# Altium schematic sheet STM32F103C8T6接口&扩展板.SchDoc
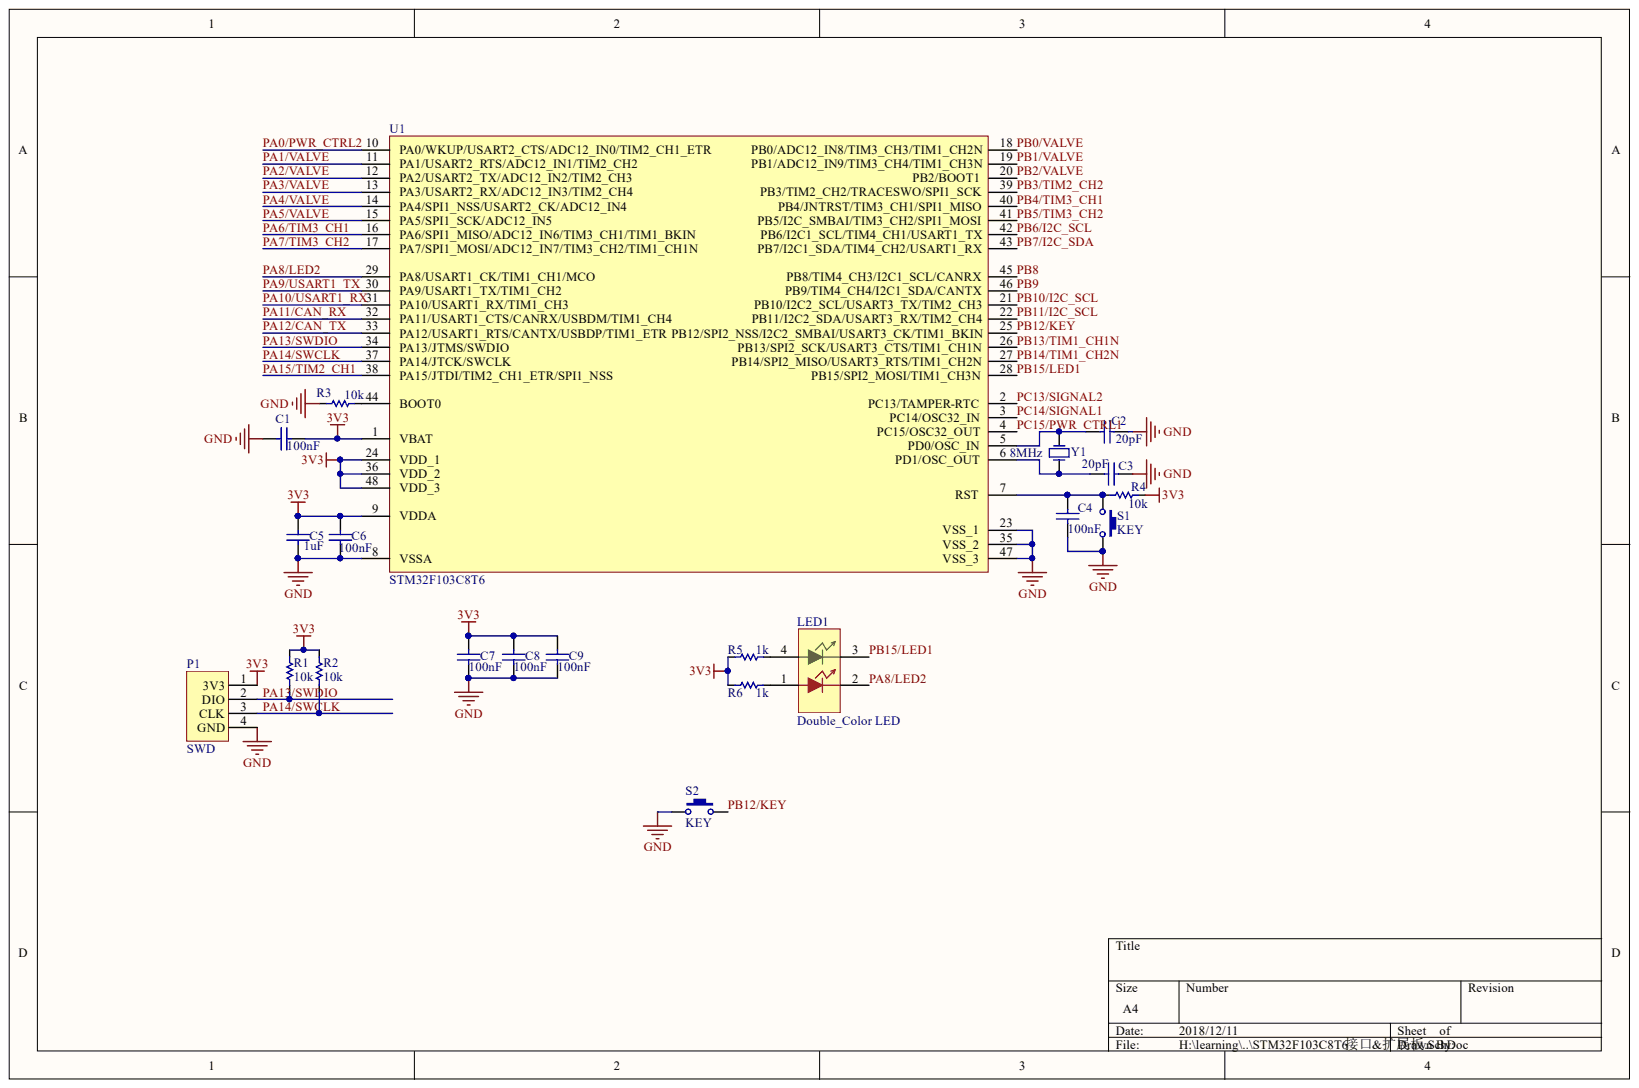
[diagram p0: STM32F103C8T6接口&扩展板.SchDoc]

## Parts
C1  100nF  [0603C]
C2  20pF  [0603C]
C3  20pF  [0603C]
C4  100nF  [0603C]
C5  1uF  [0603C]
C6  100nF  [0603C]
C7  100nF  [0603C]
C8  100nF  [0603C]
C9  100nF  [0603C]
LED1  Double_Color LED  [0805LEDX2]
P1  SWD  [HEADER 4x1]
R1  10k  [0603R]
R2  10k  [0603R]
R3  10k  [0603R]
R4  10k  [0603R]
R5  1k  [0603R]
R6  1k  [0603R]
S1  KEY  [SW 3mmx4mm]
S2  KEY  [SW 3mmx4mm]
U1  STM32F103C8T6  [LQFP_48]
Y1  8MHz  [NX5032GA]

## Nets
3V3: C1.2 C5.1 C6.1 C7.1 C8.1 C9.1 P1.1(3V3) R1.2 R2.2 R4.2 R5.1 R6.1 U1.1(VBAT) U1.9(VDDA) U1.24(VDD_1) U1.36(VDD_2) U1.48(VDD_3)
GND: C1.1 C2.2 C3.2 C4.2 C5.2 C6.2 C7.2 C8.2 C9.2 P1.4(GND) R3.2 S1.2 S2.1 U1.8(VSSA) U1.23(VSS_1) U1.35(VSS_2) U1.47(VSS_3)
PA0/PWR_CTRL2: U1.10(PA0/WKUP/USART2_CTS/ADC12_IN0/TIM2_CH1_ETR)
PA1/VALVE: U1.11(PA1/USART2_RTS/ADC12_IN1/TIM2_CH2)
PA2/VALVE: U1.12(PA2/USART2_TX/ADC12_IN2/TIM2_CH3)
PA3/VALVE: U1.13(PA3/USART2_RX/ADC12_IN3/TIM2_CH4)
PA4/VALVE: U1.14(PA4/SPI1_NSS/USART2_CK/ADC12_IN4)
PA5/VALVE: U1.15(PA5/SPI1_SCK/ADC12_IN5)
PA6/TIM3_CH1: U1.16(PA6/SPI1_MISO/ADC12_IN6/TIM3_CH1/TIM1_BKIN)
PA7/TIM3_CH2: U1.17(PA7/SPI1_MOSI/ADC12_IN7/TIM3_CH2/TIM1_CH1N)
PA8/LED2: LED1.2 U1.29(PA8/USART1_CK/TIM1_CH1/MCO)
PA9/USART1_TX: U1.30(PA9/USART1_TX/TIM1_CH2)
PA10/USART1_RX: U1.31(PA10/USART1_RX/TIM1_CH3)
PA11/CAN_RX: U1.32(PA11/USART1_CTS/CANRX/USBDM/TIM1_CH4)
PA12/CAN_TX: U1.33(PA12/USART1_RTS/CANTX/USBDP/TIM1_ETR)
PA13/SWDIO: P1.2(DIO) R1.1 U1.34(PA13/JTMS/SWDIO)
PA14/SWCLK: P1.3(CLK) R2.1 U1.37(PA14/JTCK/SWCLK)
PA15/TIM2_CH1: U1.38(PA15/JTDI/TIM2_CH1_ETR/SPI1_NSS)
PB0/VALVE: U1.18(PB0/ADC12_IN8/TIM3_CH3/TIM1_CH2N)
PB1/VALVE: U1.19(PB1/ADC12_IN9/TIM3_CH4/TIM1_CH3N)
PB2/VALVE: U1.20(PB2/BOOT1)
PB3/TIM2_CH2: U1.39(PB3/TIM2_CH2/TRACESWO/SPI1_SCK)
PB4/TIM3_CH1: U1.40(PB4/JNTRST/TIM3_CH1/SPI1_MISO)
PB5/TIM3_CH2: U1.41(PB5/I2C_SMBAI/TIM3_CH2/SPI1_MOSI)
PB6/I2C_SCL: U1.42(PB6/I2C1_SCL/TIM4_CH1/USART1_TX)
PB7/I2C_SDA: U1.43(PB7/I2C1_SDA/TIM4_CH2/USART1_RX)
PB8: U1.45(PB8/TIM4_CH3/I2C1_SCL/CANRX)
PB9: U1.46(PB9/TIM4_CH4/I2C1_SDA/CANTX)
PB10/I2C_SCL: U1.21(PB10/I2C2_SCL/USART3_TX/TIM2_CH3)
PB11/I2C_SCL: U1.22(PB11/I2C2_SDA/USART3_RX/TIM2_CH4)
PB12/KEY: S2.2 U1.25(PB12/SPI2_NSS/I2C2_SMBAI/USART3_CK/TIM1_BKIN)
PB13/TIM1_CH1N: U1.26(PB13/SPI2_SCK/USART3_CTS/TIM1_CH1N)
PB14/TIM1_CH2N: U1.27(PB14/SPI2_MISO/USART3_RTS/TIM1_CH2N)
PB15/LED1: LED1.3 U1.28(PB15/SPI2_MOSI/TIM1_CH3N)
PC13/SIGNAL2: U1.2(PC13/TAMPER-RTC)
PC14/SIGNAL1: U1.3(PC14/OSC32_IN)
PC15/PWR_CTRL1: U1.4(PC15/OSC32_OUT)
NetC2_1: C2.1 U1.5(PD0/OSC_IN) Y1.2
NetC3_1: C3.1 U1.6(PD1/OSC_OUT) Y1.1
NetC4_1: C4.1 R4.1 S1.1 U1.7(RST)
NetLED1_1: LED1.1 R6.2
NetLED1_4: LED1.4 R5.2
NetR3_1: R3.1 U1.44(BOOT0)

## Library data of the parts
C1-C9 = Cap: Capacitor; Value: 100pF; Component Kind: Standard; LatestRevisionDate: 17-Jul-2002; LatestRevisionNote: Re-released for DXP Platform.; Library Name: MyComponents_v2.3.IntLib; PackageDescription: Radial Cap, Thru-Hole; 2 Leads; 0.3 in Pin Spacing; PackageReference: RAD-0.3; Published: 8-Jun-2000; Signal Integrity: Cap; Simulation: CAP; Publisher: Altium Limited
P1 = SWD_HEADER: Component Kind: Standard; Library Name: MyComponents_v2.5.IntLib
R1-R4 = Res1: Resistor; Value: 1K; Component Kind: Standard; LatestRevisionDate: 17-Jul-2002; LatestRevisionNote: Re-released for DXP Platform.; Library Name: MyComponents_v2.3.IntLib; PackageDescription: Axial Device, Thru-Hole; 2 Leads; 0.3 in Pin Spacing; PackageReference: AXIAL-0.3; Published: 8-Jun-2000; Signal Integrity: Res; Simulation: RESISTOR; Publisher: Altium Limited
R5 R6 = Res1: Resistor; Component Kind: Standard; LatestRevisionDate: 17-Jul-2002; LatestRevisionNote: Re-released for DXP Platform.; Library Name: MyComponents_v2.5.IntLib; PackageDescription: Axial Device, Thru-Hole; 2 Leads; 0.3 in Pin Spacing; PackageReference: AXIAL-0.3; Published: 8-Jun-2000; Signal Integrity: Res; Simulation: RESISTOR; Value: 1K; Publisher: Altium Limited
S1 S2 = PushButton_2p: Button; Component Kind: Standard; LatestRevisionDate: 17-Jul-2002; LatestRevisionNote: Re-released for DXP Platform.; PackageDescription: Switch; 2 Leads; PackageReference: SPST-2; Published: 24-Mar-1999; Publisher: Altium Limited
  S1: Library Name: MyComponents_v2.3.IntLib
  S2: Library Name: MyComponents_v2.5.IntLib
U1 = STM32F103C8T6_arranged: Component Kind: Standard; Library Name: MyComponents_v2.3.IntLib
Y1 = XTAL: Crystal Oscillator; Component Kind: Standard; LatestRevisionDate: 17-Jul-2002; LatestRevisionNote: Re-released for DXP Platform.; Library Name: MyComponents_v2.3.IntLib; PackageDescription: Crystal, Thru-Hole; 2 Leads; Body 3.1 x 8.2 mm (Dia.xH); PackageReference: R38; Published: 8-Jun-2000; Simulation: XTAL; Publisher: Altium Limited
LED1 = Double_Color LED: Component Kind: Standard; Library Name: MyComponents_v2.5.IntLib
